# Altium schematic sheet Board4Shield.SchDoc
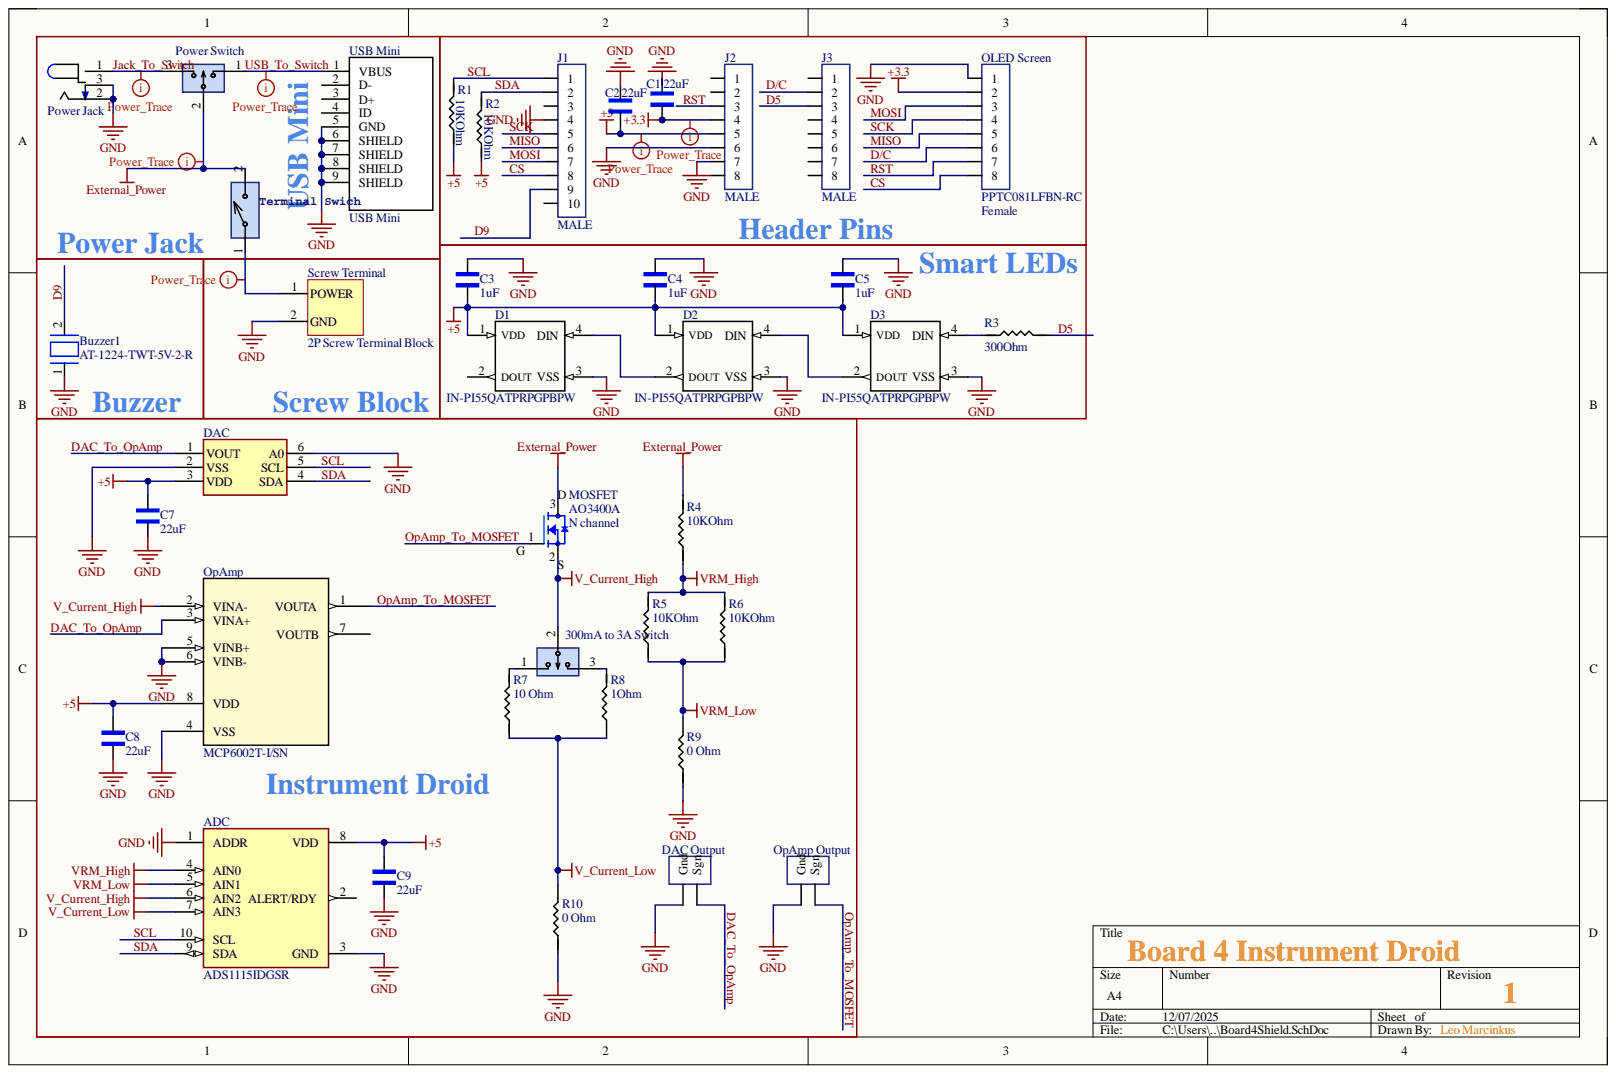
[diagram p0: Board4Shield.SchDoc]

## Parts
300mA_to_3A_Switch  PREC003SAAN-RC  [3_PIN_100mil]  (value not drawn)
ADC  ADS1115IDGSR  [ADC_ADS1115IDGSR]
Buzzer1  AT-1224-TWT-5V-2-R  [BUZZER- TH]
C1  22uF  [1206_Passive_Capacitor]
C2  22uF  [1206_Passive_Capacitor]
C3  1uF  [1206_Passive_Capacitor]
C4  1uF  [1206_Passive_Capacitor]
C5  1uF  [1206_Passive_Capacitor]
C7  22uF  [1206_Passive_Capacitor]
C8  22uF  [1206_Passive_Capacitor]
C9  22uF  [1206_Passive_Capacitor]
D1  IN-PI55QATPRPGPBPW  [SERIAL_LED - duplicate]
D2  IN-PI55QATPRPGPBPW  [SERIAL_LED - duplicate]
D3  IN-PI55QATPRPGPBPW  [SERIAL_LED - duplicate]
DAC  DAC IC  [MCP4725]  (value not drawn)
DAC_Output  10x Probe TP  [TP10x_Probe]  (value not drawn)
J1  value MALE  [10_PIN_100MIL-Male]  (hidden comment: MALE 10PIN)
J2  value MALE  [8_PIN_100MIL-Male]  (hidden comment: MALE 8PIN)
J3  value MALE  [8_PIN_100MIL-Male]  (hidden comment: MALE 8PIN)
MOSFET  AO3400A  value N channel  [N-MOSFET]
OLED_Screen  PPTC081LFBN-RC  value Female  [8_PIN_100MIL - Female]
OpAmp  MCP6002T-I/SN  [SOIC127P600X175-8N]
OpAmp_Output  10x Probe TP  [TP10x_Probe]  (value not drawn)
Power_Jack  Power Jack  [Power_Jack]
Power_Switch  PREC003SAAN-RC  [3_PIN_100mil]  (value not drawn)
R1  value 10KOhm  [1206_Passive_Resitor]  (hidden comment: 10k)
R2  value 10KOhm  [1206_Passive_Resitor]  (hidden comment: 10k)
R3  300 Ohm  value 300Ohm  [1206_Passive_Resitor]
R4  value 10KOhm  [1206_Passive_Resitor]  (hidden comment: 10k)
R5  value 10KOhm  [1206_Passive_Resitor]  (hidden comment: 10k)
R6  value 10KOhm  [1206_Passive_Resitor]  (hidden comment: 10k)
R7  10 Ohm  [1206_Passive_Resitor]
R8  1 Ohm  value 1Ohm  [1206_Passive_Resitor]
R9  0 Ohm  [1206_Passive_Resitor]
R10  0 Ohm  [1206_Passive_Resitor]
Screw_Terminal  2P Screw Terminal Block  [P_Terminal block 3.5mm-pitch]
Terminal_Swich  SW_2Pin_100mil_Switch  [2Pin-Header-Conn]  (value not drawn)
USB_Mini  USB Mini  [USB_MINI_B]

## Nets
+3.3: C1.1 J2.4 OLED_Screen.2
+5: ADC.8(VDD) C2.1 C3.1 C4.1 C5.1 C7.2 C8.2 C9.2 D1.1(VDD) D2.1(VDD) D3.1(VDD) DAC.3(VDD) J2.5 OpAmp.8(VDD) R1.1 R2.1
External_Power: MOSFET.3 Power_Switch.2 R4.2 Terminal_Swich.2
GND: ADC.1(ADDR) ADC.3(GND) Buzzer1.1 C1.2 C2.2 C3.2 C4.2 C5.2 C7.1 C8.1 C9.1 D1.3(VSS) D2.3(VSS) D3.3(VSS) DAC.2(VSS) DAC.6(A0) DAC_Output.2 J1.4 J2.6 J2.7 OLED_Screen.1 OpAmp.4(VSS) OpAmp.5(VINB+) OpAmp.6(VINB-) OpAmp_Output.2 Power_Jack.2 Power_Jack.3 R9.1 R10.1 Screw_Terminal.2 USB_Mini.5(GND) USB_Mini.6(SHIELD) USB_Mini.7(SHIELD) USB_Mini.8(SHIELD) USB_Mini.9(SHIELD)
V_Current_High: 300mA_to_3A_Switch.2 ADC.6(AIN2) MOSFET.2 OpAmp.2(VINA-)
V_Current_Low: ADC.7(AIN3) R7.1 R8.1 R10.2
VRM_High: ADC.4(AIN0) R4.1 R5.2 R6.2
VRM_Low: ADC.5(AIN1) R5.1 R6.1 R9.2
CS: J1.8 OLED_Screen.8
D5: J3.3 R3.2
D9: Buzzer1.2 J1.9
D/C: J3.2 OLED_Screen.6
DAC_To_OpAmp: DAC.1(VOUT) DAC_Output.1 OpAmp.3(VINA+)
Jack_To_Switch: Power_Jack.1 Power_Switch.3
MISO: J1.6 OLED_Screen.5
MOSI: J1.7 OLED_Screen.3
OpAmp_To_MOSFET: MOSFET.1 OpAmp.1(VOUTA) OpAmp_Output.1
RST: J2.3 OLED_Screen.7
SCK: J1.5 OLED_Screen.4
SCL: ADC.10(SCL) DAC.5(SCL) J1.1 R1.2
SDA: ADC.9(SDA) DAC.4(SDA) J1.2 R2.2
USB_To_Switch: Power_Switch.1 USB_Mini.1(VBUS)
Net300mA to 3A Switch_1: 300mA_to_3A_Switch.1 R7.2
Net300mA to 3A Switch_3: 300mA_to_3A_Switch.3 R8.2
NetD1_4: D1.4(DIN) D2.2(DOUT)
NetD2_4: D2.4(DIN) D3.2(DOUT)
NetD3_4: D3.4(DIN) R3.1
NetScrew Terminal_1: Screw_Terminal.1 Terminal_Swich.1

Not connected: ADC 2(ALERT/RDY); D1 2(DOUT); J1 3 10; J2 1 2 8; J3 1 4 5 6 7 8; OpAmp 7(VOUTB); USB_Mini 2(D-) 3(D+) 4(ID)

## Library data of the parts
300mA_to_3A_Switch Power_Switch = SW_3Pin_100mil_Switch: Connector Header Through Hole 3 position 0.100" (2.54mm); Value: CONN HEADER VERT 3POS 2.54MM; Component Kind: Standard; Digikey Link: https://www.digikey.com/product-detail/en/PREC003SAAN-RC/S1012EC-03-ND/2774851/?itemSeq=304982371; LCSC Part#: C49257; MFR Part #: PREC003SAAN-RC; Type: Extended; Library Name: ECEN5730LibUpdated.IntLib
ADC = U_ADC_ADS1115IDGSR: 16-Bit ADC with Integrated MUX, PGA, Comparator, Oscillator, and Reference 10-VSSOP -40 to 125; Component Kind: Standard; DigiKey link: https://www.digikey.com/product-detail/en/texas-instruments/ADS1115IDGSR/296-38849-1-ND/5142969?utm_source=snapeda&utm_medium=aggregator&utm_campaign=symbol; LCSC Part#: C37593; MFR Part #: ADS1115IDGSR; Package: MSOP-10 Texas Instruments; SnapEDA_Link: https://www.snapeda.com/parts/ADS1115IDGSR/Texas%20Instruments/view-part/?ref=snap; Type: Extended; Library Name: ECEN5730LibUpdated.IntLib
Buzzer1 = BUZZER_1: Buzzers Transducer, Externally Driven Electromechanical/Magnetic 5 V 40mA 2.4kHz 87dB @ 5V, 10cm Through Hole PC Pins; Component Kind: Standard; Datasheet: https://www.puiaudio.com/media/SpecSheet/AT-1224-TWT-5V-2-R.pdf; MFR Part #: AT-1224-TWT-5V-2-R; Library Name: ECEN5730LibUpdated.IntLib
C1 C2 C7-C9 = C_22uF_1206: 22uF ±10% 25V X5R 1206 Multilayer Ceramic Capacitors MLCC - SMD/SMT RoHS; MFR Part #: CL31A226KAHNNNE; Type: basic; Component Kind: Standard; Datasheet: https://datasheet.lcsc.com/lcsc/1811031514_Samsung-Electro-Mechanics-CL31A226KAHNNNE_C12891.pdf; LCSC Part#: C12891; Value: 22uF; Library Name: ECEN5730LibUpdated.IntLib
C3-C5 = C_1uF_1206: MULTILAYER CERAMIC CAPACITORS MLCC - SMD/SMT 1UF 50V 1206 ROHS; MFR Part #: CL31B105KBHNNNE; Type: Basic; Component Kind: Standard; Digikey Link: https://www.digikey.com/product-detail/en/C1206C105M4RACTU/399-8150-1-ND/3471873/?itemSeq=304982366; LCSC Part#: C1848; Value: 1uF; Library Name: ECEN5730LibUpdated.IntLib
D1-D3 = LED_Smart: LIGHT EMITTING DIODES (LED) RGB 620~630NM RED, 520~530NM GREEN, 465~475NM BLUE 600MCD@16MARED,1200MCD@16MAGREEN,300MCD@16MABLUE TOP VIEW SMD-4 ROHS; Manufacturer: XINGLIGHT; MFR Part #: XL-5050RGBC-WS2812B; Component Kind: Standard; DataSheet: https://datasheet.lcsc.com/lcsc/2301111130_XINGLIGHT-XL-5050RGBC-WS2812B_C2843785.pdf; LCSC Part#: C2843785; Library Name: ECEN5730LibUpdated.IntLib
DAC = U_MCP4725A0T-E/CH: IC DAC 12BIT V-OUT SOT23-6; Component Kind: Standard; Datasheet: https://ww1.microchip.com/downloads/en/DeviceDoc/22039d.pdf; LCSC Part#: C144198; MFR Part #: MCP4725A0T-E/CH; type: Extended; Library Name: ECEN5730LibUpdated.IntLib
DAC_Output OpAmp_Output = TP_10x_Probe: Test Point 300 mil centers; Component Kind: Standard; Library Name: ECEN5730LibUpdated.IntLib
J1 = JM_10_PIN_MALE: Pin Header 10 1 Straight ，180degrees 2.54mm Through Hole,P=2.54mm Pin Header & Female Header RoHS; Component Kind: Standard; Datasheet: https://datasheet.lcsc.com/szlcsc/1810241611_Ckmtw-Shenzhen-Cankemeng-C124372_C124372.pdf; LCSC Part#: C124372; Type: Extended; Library Name: ECEN5730LibUpdated.IntLib
J2 J3 = JM_8_PIN_MALE: Pin Header 8 1 right-angle，180degrees 2.54mm Through Hole,P=2.54mm Pin Header & Female Header RoHS; Component Kind: Standard; Datasheet: https://datasheet.lcsc.com/szlcsc/2003191006_XFCN-PZ254V-11-08P_C492407.pdf; LCSC Part#: C492407; Type: Extended; Library Name: ECEN5730LibUpdated.IntLib
MOSFET = Q_Nch_MOSFET: MOSFET N Trench 30V 5.7A 1.5V @ 250uA 26.5 m? @ 5.7A,10V SOT-23-3L RoHS; Component Kind: Standard; Datasheet: https://datasheet.lcsc.com/szlcsc/Alpha-Omega-Semicon-AOS-AO3400A_C20917.pdf; LCSC Part#: C20917; MFR Part #: AO3400A; Type: Basic; Library Name: ECEN5730LibUpdated.IntLib
OLED_Screen = JF_8_PIN_FEMALE: 8 Position Header Connector 0.100" (2.54mm) Through Hole Tin; Component Kind: Standard; Digikey Link: https://www.digikey.com/product-detail/en/PPTC081LFBN-RC/S7006-ND/810147/?itemSeq=304982354; LCSC Part#: C27438; MFR Part #: PPTC081LFBN-RC; Type: Extended; Library Name: ECEN5730LibUpdated.IntLib
OpAmp = U_MCP6002T-I/SN: 0.6 V/us 2 1MHz 1.8V ~ 6V 100μA General Purpose SOIC-8_150mil  Operational Amplifier ROHS; Mfr: Microchip; Component Kind: Standard; DataSheet: https://ww1.microchip.com/downloads/en/DeviceDoc/MCP6001-1R-1U-2-4-1-MHz-Low-Power-Op-Amp-DS20001733L.pdf; LCSC Part#: C7377; MFR Part #: MCP6002T-I/SN; Type: Basic; Library Name: ECEN5730LibUpdated.IntLib
Power_Jack = P_Power Jack: Power Barrel Connector Jack 2.10mm ID (0.083"), 5.50mm OD (0.217") Through Hole, Right Angle; MFR Part #: PJ-102A; Value: CONN PWR JACK 2X5.5MM SOLDER; Component Kind: Standard; Digikey Link: https://www.digikey.com/product-detail/en/PJ-102A/CP-102A-ND/275425/?itemSeq=304982367; LCSC Part#: C136744; Type: Extended; Library Name: ECEN5730LibUpdated.IntLib
R1 R2 R4-R6 = R_10KOhm_1206: 10 kOhms ±5% 0.25W, 1/4W Chip Resistor 1206 (3216 Metric) Automotive AEC-Q200 Thick Film; MFR Part #: RMCF1206FT10K0; Component Kind: Standard; Digikey Link: https://www.digikey.com/en/products/detail/stackpole-electronics-inc/RMCF1206FT10K0/1759669; LCSC Part#: C17902; Type: Basic; Library Name: ECEN5730LibUpdated.IntLib
R3 = R_300Ohm_1206: CHIP RESISTOR - SURFACE MOUNT 300OHMS ±1% 1/4W 1206 ROHS; MFR Part #: 1206W4F3000T5E; Component Kind: Standard; Datasheet: https://datasheet.lcsc.com/szlcsc/Uniroyal-Elec-1206W4F3000T5E_C17887.pdf; LCSC Part#: C17887; Type: Basic; Library Name: ECEN5730LibUpdated.IntLib
R7 = R_10Ohm_1206: CHIP RESISTOR - SURFACE MOUNT 0.1OHMS ±1% 1/4W 1206 ROHS; MFR Part #: 1206W4F100JT5E; Component Kind: Standard; Datasheet: https://datasheet.lcsc.com/szlcsc/Uniroyal-Elec-1206W4F100JT5E_C17903.pdf; LCSC Part#: C17903; Type: Basic; Value: 10 Ohm; Library Name: ECEN5730LibUpdated.IntLib
R8 = R_1Ohm_1206: 1Ω ±5% 0.25W ±400ppm/℃ 1206 Chip Resistor - Surface Mount RoHS; MFR Part #: 1206W4J010JT5E; Component Kind: Standard; Datasheet: https://datasheet.lcsc.com/lcsc/1810301410_UNI-ROYAL-Uniroyal-Elec-1206W4J010JT5E_C1409.pdf; LCSC Part#: C1409; Type: Extended; Library Name: ECEN5730LibUpdated.IntLib
R9 R10 = R_0Ohm_1206: CHIP RESISTOR - SURFACE MOUNT 0OHMS ±1% 1/4W 1206 ROHS; MFR Part #: 1206W4F0000T5E; Component Kind: Standard; Datasheet: https://datasheet.lcsc.com/szlcsc/Uniroyal-Elec-1206W4F0000T5E_C17888.pdf; LCSC Part#: C17888; Mouser link: https://www.mouser.com/ProductDetail/Royalohm/1206W4F0000T5E?qs=e8oIoAS2J1Thbopin%252BsagQ%3D%3D; Type: Basic; Value: 0 Ohm; Library Name: ECEN5730LibUpdated.IntLib
Screw_Terminal = P_Terminal block: Green Straight pin 3.5mm  pitch; P=3.5mm  Screw terminal ROHS; Manufacturer: Cixi Kefa Elec; MFR Part #: KF350-3.5-2P; Component Kind: Standard; DataSheet: https://datasheet.lcsc.com/lcsc/2001160007_Cixi-Kefa-Elec-KF350-3-5-2P_C474892.pdf; LCSC Part#: C474892; Library Name: ECEN5730LibUpdated.IntLib
Terminal_Swich = SW_2Pin_100mil_Switch: 2Pin Header; Component Kind: Standard; LCSC Part#: C124375; Type: Extended; Library Name: ECEN5730LibUpdated.IntLib
USB_Mini = J_USB_B_Mini: Through Hole USB Connectors RoHS; MFR Part #: 920-462A2021D10102; Value: USB mini B; Component Kind: Standard; Datasheet: https://datasheet.lcsc.com/szlcsc/2103081233_Jing-Extension-of-the-Electronic-Co-920-462A2021D10102_C46398.pdf; LCSC Part#: C46398; Type: Extended; Library Name: ECEN5730LibUpdated.IntLib
